# Altium schematic sheet STM32_MAX.SchDoc
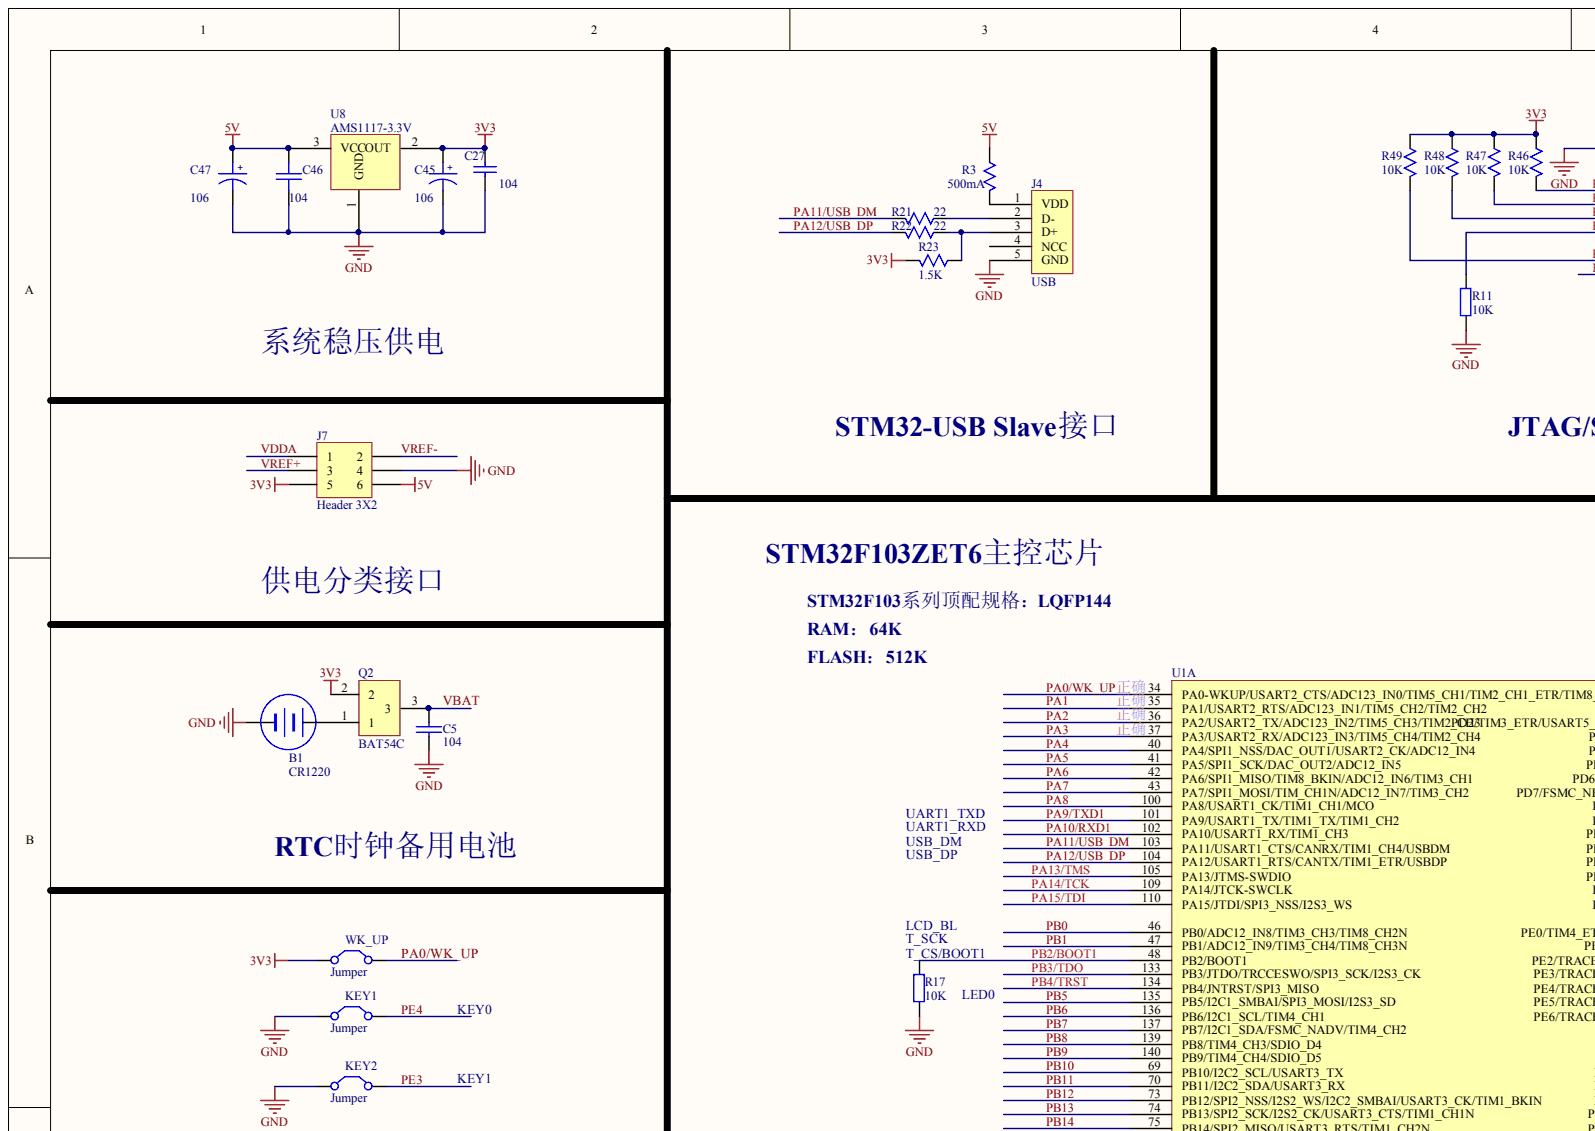
[diagram p0: STM32_MAX.SchDoc, top left]
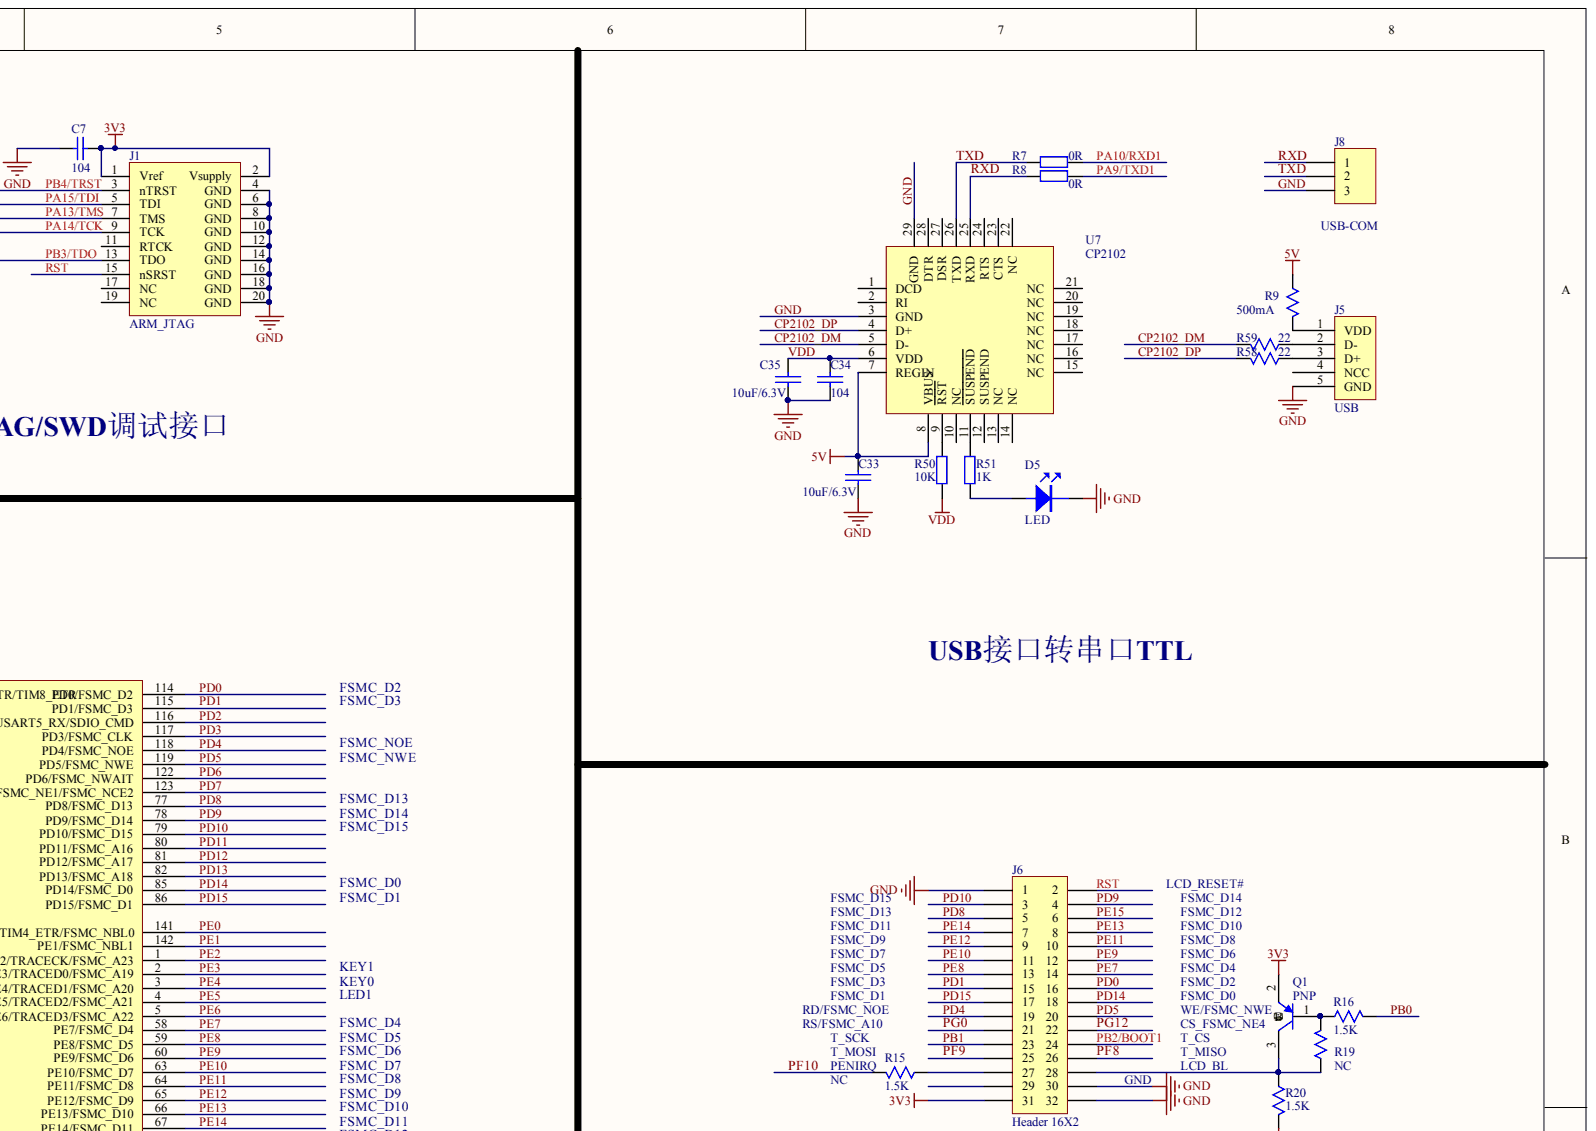
[diagram p1: STM32_MAX.SchDoc, top right]
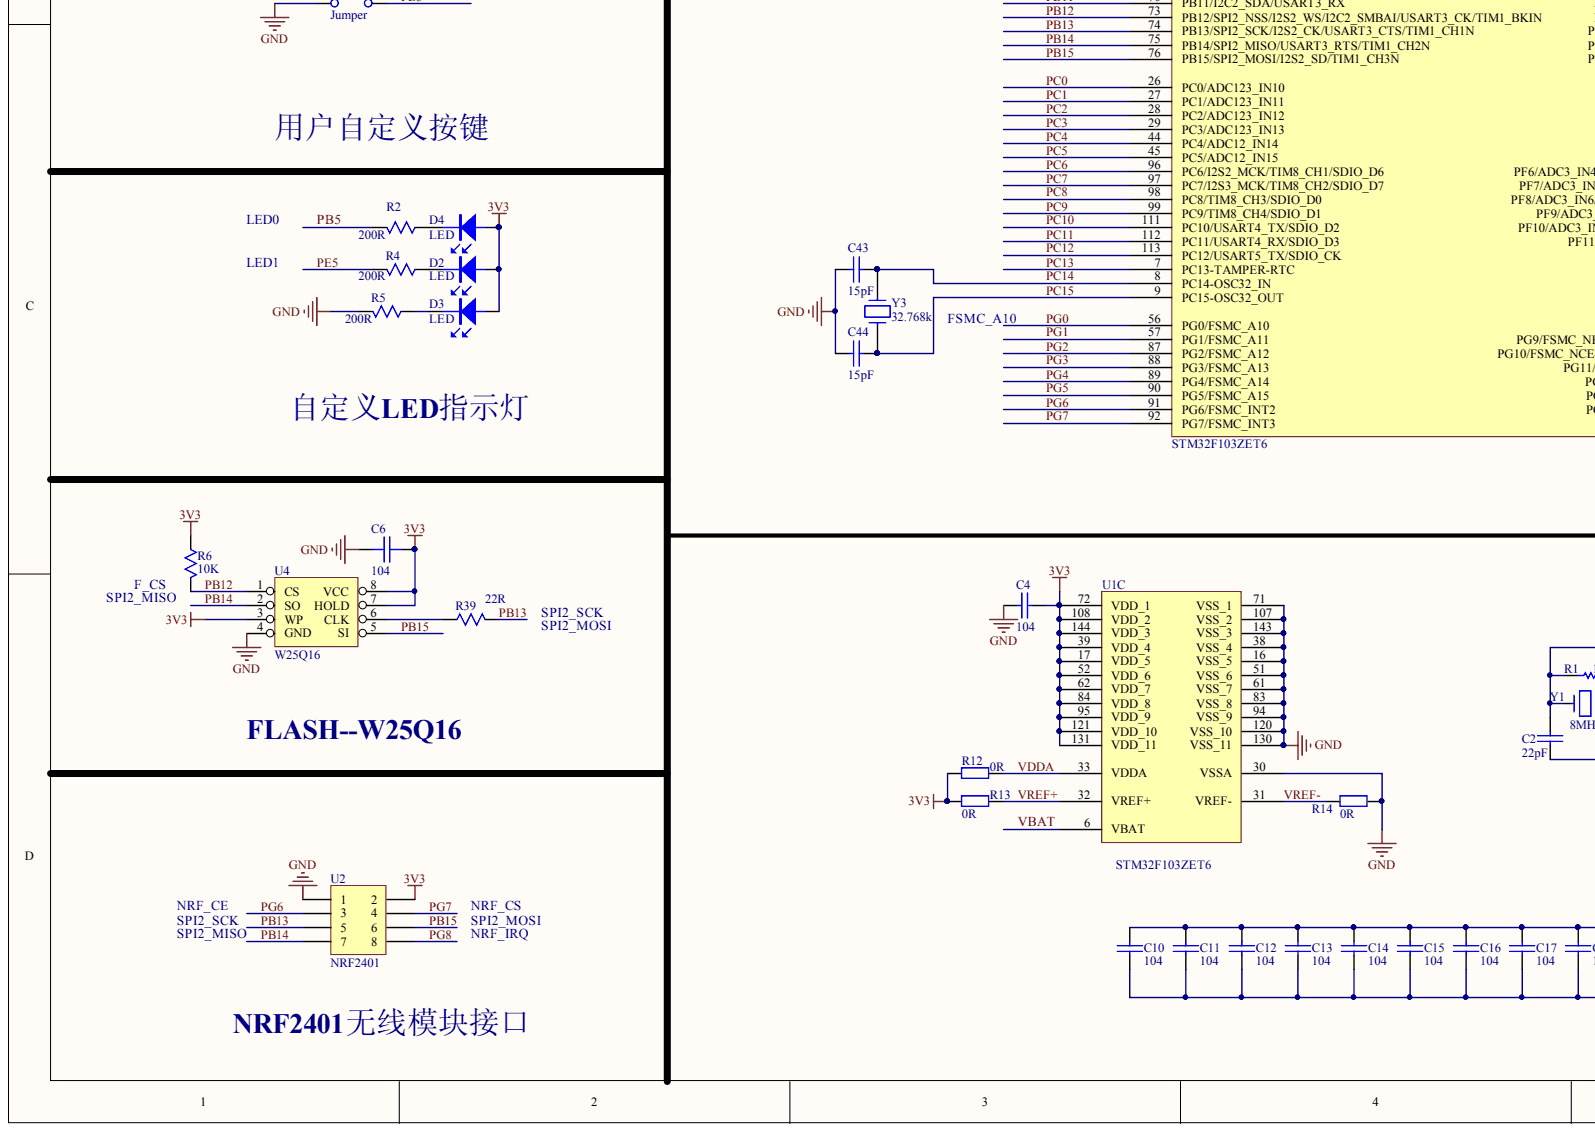
[diagram p2: STM32_MAX.SchDoc, bottom left]
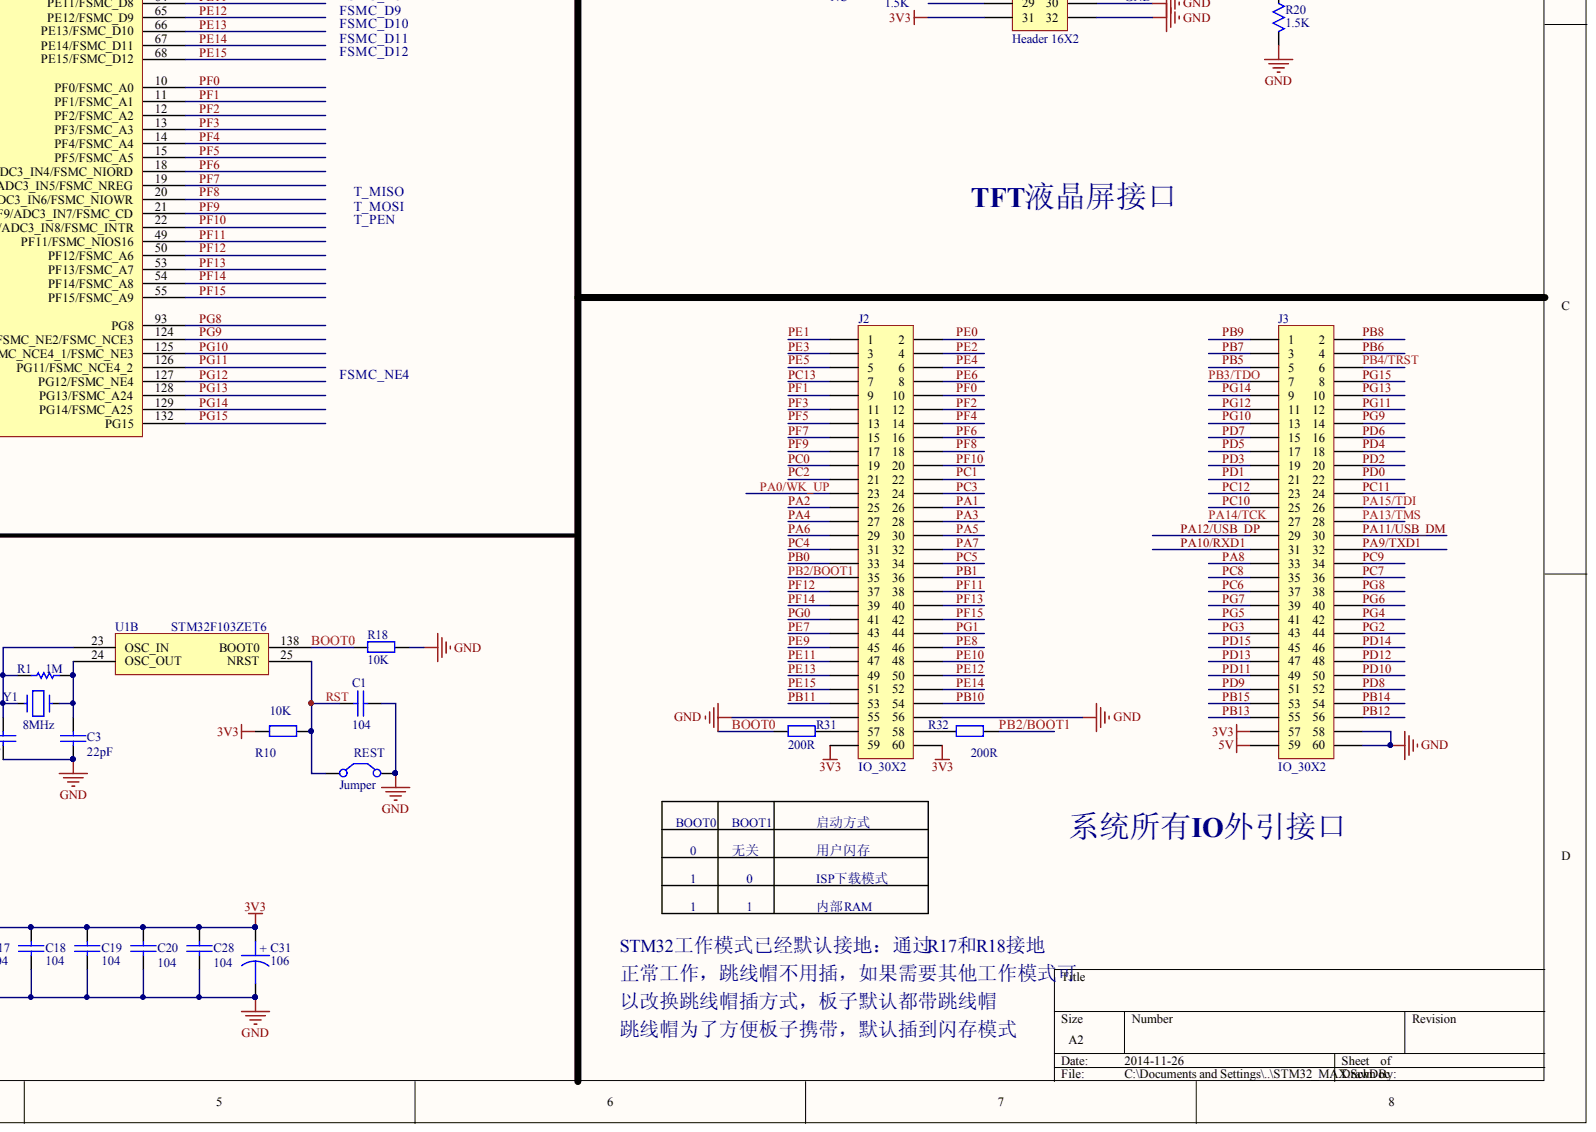
[diagram p3: STM32_MAX.SchDoc, bottom right]

## Parts
B1  CR1220  [CP1220]
C1  104  [RC0603]
C2  22pF  [RC0603]
C3  22pF  [RC0603]
C4  104  [RC0603]
C5  104  [RC0603]
C6  104  [RC0603]
C7  104  [RC0603]
C10  104  [RC0603]
C11  104  [RC0603]
C12  104  [RC0603]
C13  104  [RC0603]
C14  104  [RC0603]
C15  104  [RC0603]
C16  104  [RC0603]
C17  104  [RC0603]
C18  104  [RC0603]
C19  104  [RC0603]
C20  104  [RC0603]
C27  104  [RC0603]
C28  104  [RC0603]
C31  106  [L0805]
C33  10uF/6.3V  [RC0805]
C34  104  [RC0603]
C35  10uF/6.3V  [RC0805]
C43  15pF  [RC0603]
C44  15pF  [RC0603]
C45  106  [RC0805]
C46  104  [RC0603]
C47  106  [RC0805]
D2  LED  [LED0805]
D3  LED  [LED0805]
D4  LED  [LED0805]
D5  LED  [LED0805]
J1  ARM_JTAG  [JTAG20P]
J2  IO_30X2  [IDE2X30]
J3  IO_30X2  [IDE2X30]
J4  USB  [MINUSB]
J5  USB  [MINUSB]
J6  Header 16X2  [IDE2X16-2.54]
J7  Header 3X2  [IDE2X6-2.54]
J8  USB-COM  [HDR1X3]
KEY1  Jumper  [key]
KEY2  Jumper  [key]
Q1  PNP  [PNP_SOT23]
Q2  BAT54C  [BAT54C]
R1  1M  [RC0603]
R2  200R  [RC0603]
R3  500mA  [RC0805]
R4  200R  [RC0603]
R5  200R  [RC0603]
R6  10K  [RC0603]
R7  0R  [RC0603]
R8  0R  [RC0603]
R9  500mA  [RC0805]
R10  10K  [RC0603]
R11  10K  [RC0603]
R12  0R  [RC0603]
R13  0R  [RC0603]
R14  0R  [RC0603]
R15  1.5K  [RC0603]
R16  1.5K  [RC0603]
R17  10K  [RC0603]
R18  10K  [RC0603]
R19  NC  [RC0603]
R20  1.5K  [RC0603]
R21  22  [RC0603]
R22  22  [RC0603]
R23  1.5K  [RC0603]
R31  200R  [RC0603]
R32  200R  [RC0603]
R39  22R  [RC0603]
R46  10K  [RC0603]
R47  10K  [RC0603]
R48  10K  [RC0603]
R49  10K  [RC0603]
R50  10K  [RC0603]
R51  1K  [RC0603]
R58  22  [RC0603]
R59  22  [RC0603]
REST  Jumper  [key]
U1  STM32F103ZET6  [LQFP144N]  (parts A,B,C)
U2  NRF2401  [2*4]
U4  W25Q16  [SOIC8P]
U7  CP2102  [QFN28P]
U8  AMS1117-3.3V  [SOT223]
WK_UP  Jumper  [key]
Y1  8MHz  [SMD49S]
Y3  32.768k  [32.768k]

## Nets
3V3: C4.2 C6.2 C7.2 C10.1 C11.1 C12.1 C13.1 C14.1 C15.1 C16.1 C17.1 C18.1 C19.1 C20.1 C27.1 C28.1 C31.1 C45.1 D2.1 D3.1 D4.1 J1.1(Vref) J1.2(Vsupply) J2.59 J2.60 J3.57 J6.31 J7.5 Q1.2 Q2.2 R6.1 R10.2 R12.1 R13.1 R23.2 R46.1 R47.1 R48.1 R49.1 U1.17(VDD_5) U1.39(VDD_4) U1.52(VDD_6) U1.62(VDD_7) U1.72(VDD_1) U1.84(VDD_8) U1.95(VDD_9) U1.108(VDD_2) U1.121(VDD_10) U1.131(VDD_11) U1.144(VDD_3) U2.2 U4.3(WP) U4.7(HOLD) U4.8(VCC) U8.2 WK_UP.1
5V: C33.1 C46.1 C47.1 J3.59 J7.6 R3.1 R9.1 U7.7(REGIN) U7.8(VBUS) U8.3
BOOT0: R18.1 R31.2 U1.138(BOOT0)
CP2102_DM: R59.2 U7.5(D-)
CP2102_DP: R58.2 U7.4(D+)
GND: B1.2 C1.1 C2.2 C3.2 C4.1 C5.2 C6.1 C7.1 C10.2 C11.2 C12.2 C13.2 C14.2 C15.2 C16.2 C17.2 C18.2 C19.2 C20.2 C27.2 C28.2 C31.2 C33.2 C34.2 C35.2 C43.1 C44.1 C45.2 C46.2 C47.2 D5.2 J1.4(GND) J1.6(GND) J1.8(GND) J1.10(GND) J1.12(GND) J1.14(GND) J1.16(GND) J1.18(GND) J1.20(GND) J2.55 J2.56 J3.58 J3.60 J4.5(GND) J5.5(GND) J6.1 J6.30 J6.32 J7.4 J8.3 KEY1.1 KEY2.1 R5.2 R11.1 R14.2 R17.2 R18.2 R20.2 REST.2 U1.16(VSS_5) U1.30(VSSA) U1.38(VSS_4) U1.51(VSS_6) U1.61(VSS_7) U1.71(VSS_1) U1.83(VSS_8) U1.94(VSS_9) U1.107(VSS_2) U1.120(VSS_10) U1.130(VSS_11) U1.143(VSS_3) U2.1 U4.4(GND) U7.3(GND) U7.29(GND) U8.1
PA0/WK_UP: J2.23 U1.34(PA0-WKUP/USART2_CTS/ADC123_IN0/TIM5_CH1/TIM2_CH1_ETR/TIM8_ETR) WK_UP.2
PA1: J2.26 U1.35(PA1/USART2_RTS/ADC123_IN1/TIM5_CH2/TIM2_CH2)
PA2: J2.25 U1.36(PA2/USART2_TX/ADC123_IN2/TIM5_CH3/TIM2_CH3)
PA3: J2.28 U1.37(PA3/USART2_RX/ADC123_IN3/TIM5_CH4/TIM2_CH4)
PA4: J2.27 U1.40(PA4/SPI1_NSS/DAC_OUT1/USART2_CK/ADC12_IN4)
PA5: J2.30 U1.41(PA5/SPI1_SCK/DAC_OUT2/ADC12_IN5)
PA6: J2.29 U1.42(PA6/SPI1_MISO/TIM8_BKIN/ADC12_IN6/TIM3_CH1)
PA7: J2.32 U1.43(PA7/SPI1_MOSI/TIM_CH1N/ADC12_IN7/TIM3_CH2)
PA8: J3.33 U1.100(PA8/USART1_CK/TIM1_CH1/MCO)
PA9/TXD1: J3.32 R8.1 U1.101(PA9/USART1_TX/TIM1_TX/TIM1_CH2)
PA10/RXD1: J3.31 R7.1 U1.102(PA10/USART1_RX/TIM1_CH3)
PA11/USB_DM: J3.30 R21.2 U1.103(PA11/USART1_CTS/CANRX/TIM1_CH4/USBDM)
PA12/USB_DP: J3.29 R22.2 U1.104(PA12/USART1_RTS/CANTX/TIM1_ETR/USBDP)
PA13/TMS: J1.7(TMS) J3.28 R48.2 U1.105(PA13/JTMS-SWDIO)
PA14/TCK: J1.9(TCK) J3.27 R11.2 U1.109(PA14/JTCK-SWCLK)
PA15/TDI: J1.5(TDI) J3.26 R47.2 U1.110(PA15/JTDI/SPI3_NSS/I2S3_WS)
PB0: J2.33 R16.2 U1.46(PB0/ADC12_IN8/TIM3_CH3/TIM8_CH2N)
PB1: J2.36 J6.23 U1.47(PB1/ADC12_IN9/TIM3_CH4/TIM8_CH3N)
PB2/BOOT1: J2.35 J6.24 R17.1 R32.1 U1.48(PB2/BOOT1)
PB3/TDO: J1.13(TDO) J3.7 R49.2 U1.133(PB3/JTDO/TRCCESWO/SPI3_SCK/I2S3_CK)
PB4/TRST: J1.3(nTRST) J3.6 R46.2 U1.134(PB4/JNTRST/SPI3_MISO)
PB5: J3.5 R2.2 U1.135(PB5/I2C1_SMBAI/SPI3_MOSI/I2S3_SD)
PB6: J3.4 U1.136(PB6/I2C1_SCL/TIM4_CH1)
PB7: J3.3 U1.137(PB7/I2C1_SDA/FSMC_NADV/TIM4_CH2)
PB8: J3.2 U1.139(PB8/TIM4_CH3/SDIO_D4)
PB9: J3.1 U1.140(PB9/TIM4_CH4/SDIO_D5)
PB10: J2.54 U1.69(PB10/I2C2_SCL/USART3_TX)
PB11: J2.53 U1.70(PB11/I2C2_SDA/USART3_RX)
PB12: J3.56 R6.2 U1.73(PB12/SPI2_NSS/I2S2_WS/I2C2_SMBAI/USART3_CK/TIM1_BKIN) U4.1(CS)
PB13: J3.55 R39.2 U1.74(PB13/SPI2_SCK/I2S2_CK/USART3_CTS/TIM1_CH1N) U2.5
PB14: J3.54 U1.75(PB14/SPI2_MISO/USART3_RTS/TIM1_CH2N) U2.7 U4.2(SO)
PB15: J3.53 U1.76(PB15/SPI2_MOSI/I2S2_SD/TIM1_CH3N) U2.6 U4.5(SI)
PC0: J2.19 U1.26(PC0/ADC123_IN10)
PC1: J2.22 U1.27(PC1/ADC123_IN11)
PC2: J2.21 U1.28(PC2/ADC123_IN12)
PC3: J2.24 U1.29(PC3/ADC123_IN13)
PC4: J2.31 U1.44(PC4/ADC12_IN14)
PC5: J2.34 U1.45(PC5/ADC12_IN15)
PC6: J3.37 U1.96(PC6/I2S2_MCK/TIM8_CH1/SDIO_D6)
PC7: J3.36 U1.97(PC7/I2S3_MCK/TIM8_CH2/SDIO_D7)
PC8: J3.35 U1.98(PC8/TIM8_CH3/SDIO_D0)
PC9: J3.34 U1.99(PC9/TIM8_CH4/SDIO_D1)
PC10: J3.25 U1.111(PC10/USART4_TX/SDIO_D2)
PC11: J3.24 U1.112(PC11/USART4_RX/SDIO_D3)
PC12: J3.23 U1.113(PC12/USART5_TX/SDIO_CK)
PC13: J2.7 U1.7(PC13-TAMPER-RTC)
PC14: C43.2 U1.8(PC14-OSC32_IN) Y3.2
PC15: C44.2 U1.9(PC15-OSC32_OUT) Y3.1
PD0: J3.22 J6.16 U1.114(PD0/FSMC_D2)
PD1: J3.21 J6.15 U1.115(PD1/FSMC_D3)
PD2: J3.20 U1.116(PD2/TIM3_ETR/USART5_RX/SDIO_CMD)
PD3: J3.19 U1.117(PD3/FSMC_CLK)
PD4: J3.18 J6.19 U1.118(PD4/FSMC_NOE)
PD5: J3.17 J6.20 U1.119(PD5/FSMC_NWE)
PD6: J3.16 U1.122(PD6/FSMC_NWAIT)
PD7: J3.15 U1.123(PD7/FSMC_NE1/FSMC_NCE2)
PD8: J3.52 J6.5 U1.77(PD8/FSMC_D13)
PD9: J3.51 J6.4 U1.78(PD9/FSMC_D14)
PD10: J3.50 J6.3 U1.79(PD10/FSMC_D15)
PD11: J3.49 U1.80(PD11/FSMC_A16)
PD12: J3.48 U1.81(PD12/FSMC_A17)
PD13: J3.47 U1.82(PD13/FSMC_A18)
PD14: J3.46 J6.18 U1.85(PD14/FSMC_D0)
PD15: J3.45 J6.17 U1.86(PD15/FSMC_D1)
PE0: J2.2 U1.141(PE0/TIM4_ETR/FSMC_NBL0)
PE1: J2.1 U1.142(PE1/FSMC_NBL1)
PE2: J2.4 U1.1(PE2/TRACECK/FSMC_A23)
PE3: J2.3 KEY2.2 U1.2(PE3/TRACED0/FSMC_A19)
PE4: J2.6 KEY1.2 U1.3(PE4/TRACED1/FSMC_A20)
PE5: J2.5 R4.2 U1.4(PE5/TRACED2/FSMC_A21)
PE6: J2.8 U1.5(PE6/TRACED3/FSMC_A22)
PE7: J2.43 J6.14 U1.58(PE7/FSMC_D4)
PE8: J2.46 J6.13 U1.59(PE8/FSMC_D5)
PE9: J2.45 J6.12 U1.60(PE9/FSMC_D6)
PE10: J2.48 J6.11 U1.63(PE10/FSMC_D7)
PE11: J2.47 J6.10 U1.64(PE11/FSMC_D8)
PE12: J2.50 J6.9 U1.65(PE12/FSMC_D9)
PE13: J2.49 J6.8 U1.66(PE13/FSMC_D10)
PE14: J2.52 J6.7 U1.67(PE14/FSMC_D11)
PE15: J2.51 J6.6 U1.68(PE15/FSMC_D12)
PF0: J2.10 U1.10(PF0/FSMC_A0)
PF1: J2.9 U1.11(PF1/FSMC_A1)
PF2: J2.12 U1.12(PF2/FSMC_A2)
PF3: J2.11 U1.13(PF3/FSMC_A3)
PF4: J2.14 U1.14(PF4/FSMC_A4)
PF5: J2.13 U1.15(PF5/FSMC_A5)
PF6: J2.16 U1.18(PF6/ADC3_IN4/FSMC_NIORD)
PF7: J2.15 U1.19(PF7/ADC3_IN5/FSMC_NREG)
PF8: J2.18 J6.26 U1.20(PF8/ADC3_IN6/FSMC_NIOWR)
PF9: J2.17 J6.25 U1.21(PF9/ADC3_IN7/FSMC_CD)
PF10: J2.20 R15.2 U1.22(PF10/ADC3_IN8/FSMC_INTR)
PF11: J2.38 U1.49(PF11/FSMC_NIOS16)
PF12: J2.37 U1.50(PF12/FSMC_A6)
PF13: J2.40 U1.53(PF13/FSMC_A7)
PF14: J2.39 U1.54(PF14/FSMC_A8)
PF15: J2.42 U1.55(PF15/FSMC_A9)
PG0: J2.41 J6.21 U1.56(PG0/FSMC_A10)
PG1: J2.44 U1.57(PG1/FSMC_A11)
PG2: J3.44 U1.87(PG2/FSMC_A12)
PG3: J3.43 U1.88(PG3/FSMC_A13)
PG4: J3.42 U1.89(PG4/FSMC_A14)
PG5: J3.41 U1.90(PG5/FSMC_A15)
PG6: J3.40 U1.91(PG6/FSMC_INT2) U2.3
PG7: J3.39 U1.92(PG7/FSMC_INT3) U2.4
PG8: J3.38 U1.93(PG8) U2.8
PG9: J3.14 U1.124(PG9/FSMC_NE2/FSMC_NCE3)
PG10: J3.13 U1.125(PG10/FSMC_NCE4_1/FSMC_NE3)
PG11: J3.12 U1.126(PG11/FSMC_NCE4_2)
PG12: J3.11 J6.22 U1.127(PG12/FSMC_NE4)
PG13: J3.10 U1.128(PG13/FSMC_A24)
PG14: J3.9 U1.129(PG14/FSMC_A25)
PG15: J3.8 U1.132(PG15)
RST: C1.2 J1.15(nSRST) J6.2 R10.1 REST.1 U1.25(NRST)
RXD: J8.1 R8.2 U7.25(RXD)
TXD: J8.2 R7.2 U7.26(TXD)
VBAT: C5.1 Q2.3 U1.6(VBAT)
VDD: C34.1 C35.1 R50.2 U7.6(VDD)
VDDA: J7.1 R12.2 U1.33(VDDA)
VREF+: J7.3 R13.2 U1.32(VREF+)
VREF-: J7.2 R14.1 U1.31(VREF-)
NetB1_1: B1.1 Q2.1
NetC2_1: C2.1 R1.1 U1.23(OSC_IN) Y1.1
NetC3_1: C3.1 R1.2 U1.24(OSC_OUT) Y1.2
NetD2_2: D2.2 R4.1
NetD3_2: D3.2 R5.1
NetD4_2: D4.2 R2.1
NetD5_1: D5.1 R51.2
NetJ2_57: J2.57 R31.1
NetJ2_58: J2.58 R32.2
NetJ4_1: J4.1(VDD) R3.2
NetJ4_2: J4.2(D-) R21.1
NetJ4_3: J4.3(D+) R22.1 R23.1
NetJ5_1: J5.1(VDD) R9.2
NetJ5_2: J5.2(D-) R59.1
NetJ5_3: J5.3(D+) R58.1
NetJ6_27: J6.27 R15.1
NetJ6_28: J6.28 Q1.3 R19.1 R20.1
NetQ1_1: Q1.1 R16.1 R19.2
NetR39_1: R39.1 U4.6(CLK)
NetR50_1: R50.1 U7.9(RST)
NetR51_1: R51.1 U7.11(SUSPEND)

Not connected: J1 11(RTCK) 17(NC) 19(NC); J4 4(NCC); J5 4(NCC); J6 29; U7 1(DCD) 2(RI) 10(NC) 12(SUSPEND) 13(NC) 14(NC) 15(NC) 16(NC) 17(NC) 18(NC) 19(NC) 20(NC) 21(NC) 22(NC) 23(CTS) 24(RTS) 27(DSR) 28(DTR)

## Library data of the parts
B1 = BATTERY: Component Kind: Standard; Library Name: YT1_SCH_99SE.SCHLIB
C1-C5 C10-C20 C28 C43 C44 = CAP: Capcitor; Component Kind: Standard; Library Name: LXKJSJ.SchLib
C6 C46 = CAP: Component Kind: Standard; Library Name: YT1_SCH_99SE.SCHLIB
C7 C27 = Cap: Capacitor; Component Kind: Standard; LatestRevisionDate: 17-Jul-2002; LatestRevisionNote: Re-released for DXP Platform.; PackageReference: RAD-0.3; Published: 8-Jun-2000; Publisher: Altium Limited
C31 = CAPACITOR POL: Capacitor; Component Kind: Standard
C33-C35 = CAP: Capacitor; Component Kind: Standard
C45 C47 = CAP2: Component Kind: Standard; Library Name: YT1_SCH_99SE.SCHLIB
J1 = JTAG: Component Kind: Standard; Description: JTAG; Library Name: LXKJSJ.SchLib
J2 J3 = Header 30X2: Header, 30-Pin, Dual row; Component Kind: Standard; LatestRevisionDate: 17-Jul-2002; LatestRevisionNote: Re-released for DXP Platform.; Library Name: Miscellaneous Connectors.IntLib; Signal Integrity: Connector; Publisher: Altium Limited
J6 = Header 16X2: Header, 16-Pin, Dual row; Component Kind: Standard; LatestRevisionDate: 17-Jul-2002; LatestRevisionNote: Re-released for DXP Platform.; Library Name: Miscellaneous Connectors.IntLib; Signal Integrity: Connector; Publisher: Altium Limited
J7 = Header 3X2: Header, 3-Pin, Dual row; Component Kind: Standard; LatestRevisionDate: 17-Jul-2002; LatestRevisionNote: Re-released for DXP Platform.; Library Name: Miscellaneous Connectors.IntLib; Signal Integrity: Connector; Publisher: Altium Limited
J8 = Header 3: Header, 3-Pin; Component Kind: Standard; LatestRevisionDate: 17-Jul-2002; LatestRevisionNote: Re-released for DXP Platform.; Library Name: Miscellaneous Connectors.IntLib; Signal Integrity: Connector; Publisher: Altium Limited
KEY1 KEY2 REST WK_UP = Jumper: Jumper Wire; Component Kind: Standard; LatestRevisionDate: 17-Jul-2002; LatestRevisionNote: Re-released for DXP Platform.; Library Name: Free Documents.IntLib; PackageReference: RAD-0.2; Published: 24-Mar-1999; Publisher: Altium Limited
Q1 = PNP: PNP Bipolar Transistor; Component Kind: Standard; LatestRevisionDate: 29-May-2009; LatestRevisionNote: IPC-7351 Footprint Added.; Library Name: Miscellaneous Devices.IntLib; PackageDescription: 3-Pin SOT-23 Package 0.95 mm Pitch, 2.4 mm Lead Span; PackageReference: SOT-23B; PackageVersion: Aug-1999; Published: 8-Jun-2000; Publisher: Altium Limited
R1 = Res1: Resistor; Component Kind: Standard; Library Name: Miscellaneous Devices.IntLib; Value: 1M
R2-R6 R9 R15 R16 R19-R23 R39 R46-R49 R58 R59 = RES: Component Kind: Standard; Library Name: YT1_SCH_99SE.SCHLIB
R7 R8 R10-R14 R17 R18 R31 R32 R50 R51 = Res2: Resistor; Component Kind: Standard; Library Name: Miscellaneous Devices.IntLib
U1 = STM32F103ZET6_1: Component Kind: Standard; Library Name: LYF_Library.IntLib
U2 = Header 4X2: Header, 4-Pin, Dual row; Component Kind: Standard; LatestRevisionDate: 17-Jul-2002; LatestRevisionNote: Re-released for DXP Platform.; Library Name: Miscellaneous Connectors.IntLib; Signal Integrity: Connector; Publisher: Altium Limited
U4 = W25X16: Component Kind: Standard; Library Name: YT1_SCH_99SE.SCHLIB
U8 = 11: Component Kind: Standard
Y1 = XTAL: Crystal Oscillator; Component Kind: Standard; Library Name: LXKJSJ.SchLib
Y3 = CRYSTAL: Crystal 8MHz PLUG; Component Kind: Standard; Value: 8MHz
D2-D5 = LED: Component Kind: Standard
J4 J5 = usb: Component Kind: Standard; Library Name: YT1_SCH_99SE.SCHLIB
Q2 = BAT54C: Component Kind: Standard; Library Name: Newcapec9263.SchLib
U7 = CP2102: Component Kind: Standard; Library Name: BitCloud_Base.SchLib
